# Altium schematic sheet alimentation.SchDoc
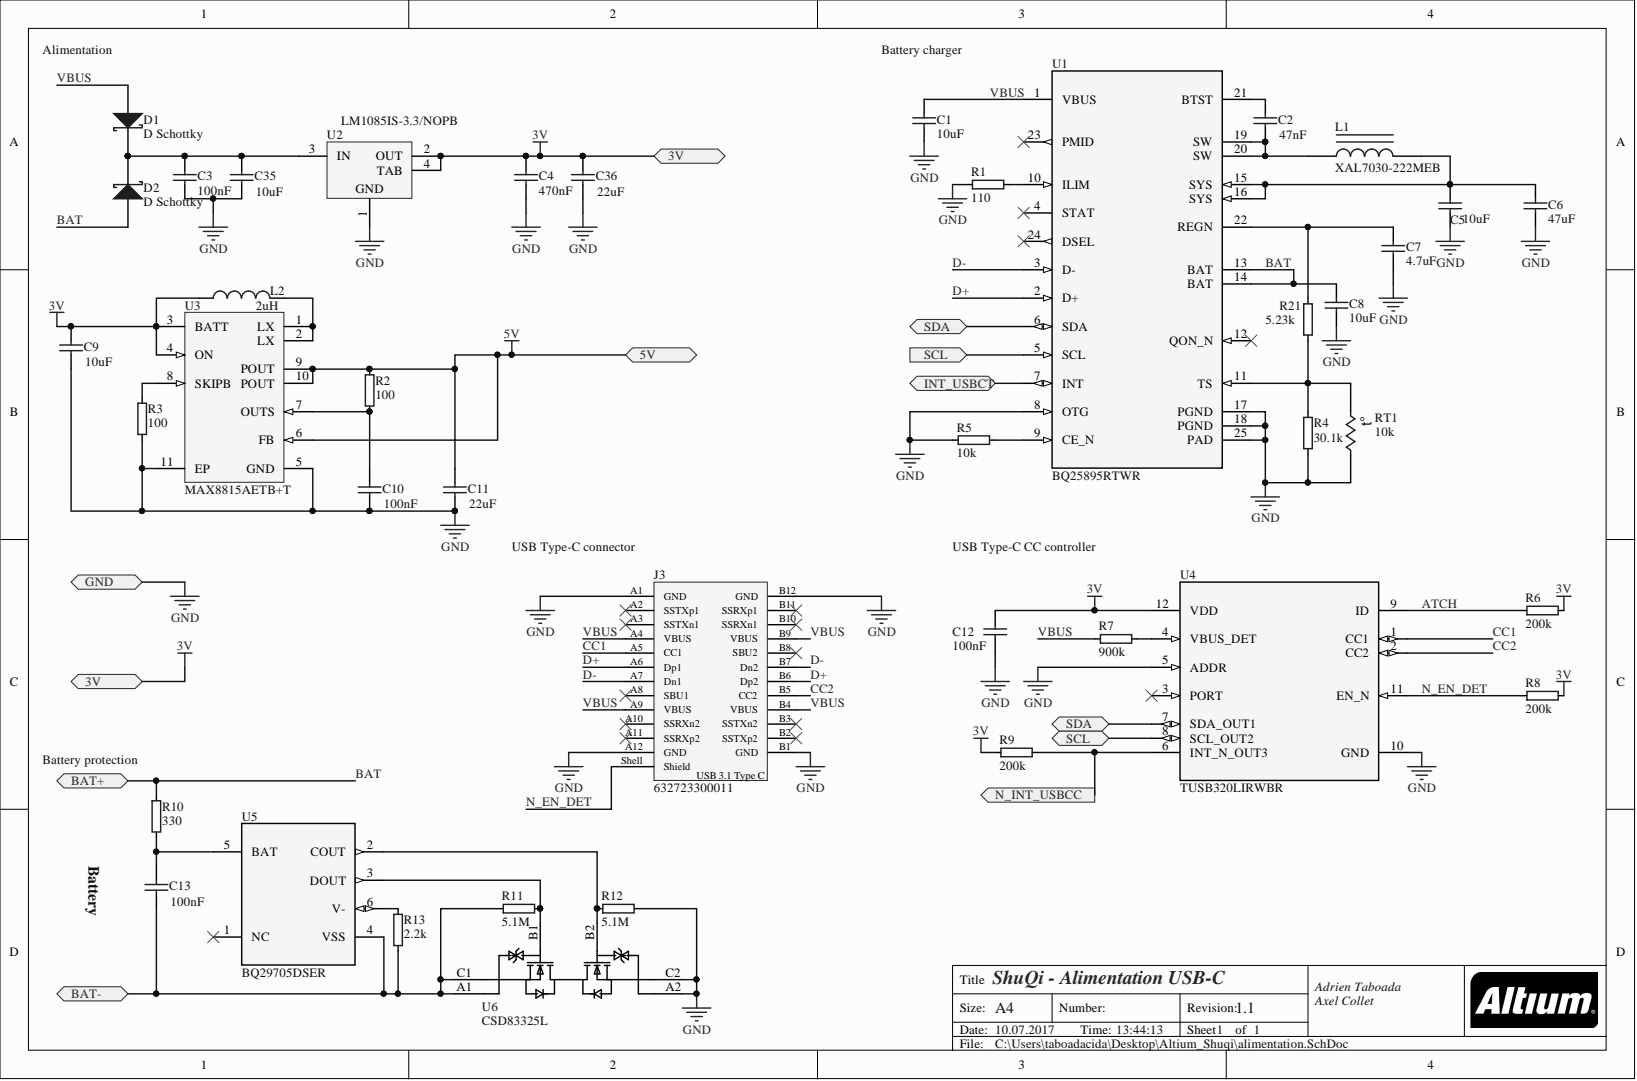
[diagram p0: alimentation.SchDoc]

## Parts
C1  10uF  [1608[0603]]
C2  47nF  [1608[0603]]
C3  100nF  [1608[0603]]
C4  470nF  [1608[0603]]
C5  10uF  [1608[0603]]
C6  47uF  [1608[0603]]
C7  4.7uF  [1608[0603]]
C8  10uF  [1608[0603]]
C9  10uF  [1608[0603]]
C10  100nF  [1608[0603]]
C11  22uF  [1608[0603]]
C12  100nF  [1608[0603]]
C13  100nF  [1608[0603]]
C35  10uF  [1608[0603]]
C36  22uF  [1608[0603]]
D1  D Schottky  [SMB]
D2  D Schottky  [SMB]
J3  632723300011  Interface Type: Type C  Sub-Family: USB 3.1  [632723300011]
L1  XAL7030-222MEB  [DFN472P750X310-2N]
L2  2uH  [TYS80_Inductance]
R1  110  [RESC1005X04L]
R2  100  [RESC1005X04L]
R3  100  [RESC1005X04L]
R4  30.1k  [RESC1005X04L]
R5  10k  [RESC1005X04L]
R6  200k  [RESC1005X04L]
R7  900k  [RESC1005X04L]
R8  200k  [RESC1005X04L]
R9  200k  [RESC1005X04L]
R10  330  [RESC1005X04L]
R11  5.1M  [RESC1005X04L]
R12  5.1M  [RESC1005X04L]
R13  2.2k  [RESC1005X04L]
R21  5.23k  [RESC1005X04L]
RT1  10k  [6-0805_N]
U1  BQ25895RTWR  [RTW0024H]
U2  LM1085IS-3.3/NOPB  [KTT0003B_M]
U3  MAX8815AETB+T  [T1033+2_M]
U4  TUSB320LIRWBR  [RWB0012A]
U5  BQ29705DSER  [DSE0006A]
U6  CSD83325L  [YJE0006A]

## Ports
3V, 5V, BAT+, BAT-, GND, INT_USBCT, N_INT_USBCC, SCL, SDA

## Nets
3V: C4.2 C9.2 C12.2 C36.2 L2.1 R6.1 R8.1 R9.2 U2.2(OUT) U2.4(TAB) U3.3(BATT) U3.4(ON) U4.12(VDD) ; port 3V
5V: C11.2 R2.2 U3.6(FB) U3.9(POUT) U3.10(POUT) ; port 5V
ATCH: R6.2 U4.9(ID)
BAT: C8.1 D2.1 R10.2 U1.13(BAT) U1.14(BAT)
CC1: J3.A5(CC1) U4.1(CC1)
CC2: J3.B5(CC2) U4.2(CC2)
D+: J3.A6(Dp1) J3.B6(Dp2) U1.2(D+)
D-: J3.A7(Dn1) J3.B7(Dn2) U1.3(D-)
GND: C1.2 C3.1 C4.1 C5.2 C6.2 C7.2 C8.2 C9.1 C10.1 C11.1 C12.1 C35.1 C36.1 J3.A1(GND) J3.A12(GND) J3.B1(GND) J3.B12(GND) R1.2 R3.1 R4.1 R5.2 R12.1 RT1.2 U1.8(OTG) U1.17(PGND) U1.18(PGND) U1.25(PAD) U2.1 U3.5(GND) U3.11(EP) U4.5(ADDR) U4.10(GND) U6.A2 U6.C2 ; port GND
INT_USBCT: U1.7(INT) ; port INT_USBCT
N_EN_DET: J3.Shell(Shield) R8.2 U4.11(EN_N)
N_INT_USBCC: R9.1 U4.6(INT_N_OUT3) ; port N_INT_USBCC
SCL: U1.5(SCL) U4.8(SCL_OUT2) ; port SCL
SDA: U1.6(SDA) U4.7(SDA_OUT1) ; port SDA
VBUS: C1.1 D1.1 J3.A4(VBUS) J3.A9(VBUS) J3.B4(VBUS) J3.B9(VBUS) R7.2 U1.1(VBUS)
NetC2_1: C2.1 U1.21(BTST)
NetC2_2: C2.2 L1.1 U1.19(SW) U1.20(SW)
NetC3_2: C3.2 C35.2 D1.2 D2.2 U2.3(IN)
NetC5_1: C5.1 C6.1 L1.2 U1.15(SYS) U1.16(SYS)
NetC7_1: C7.1 R21.2 U1.22(REGN)
NetC10_2: C10.2 R2.1 U3.7(OUTS)
NetC13_1: C13.1 R11.2 R13.1 U5.4(VSS) U6.A1 U6.C1
NetC13_2: C13.2 R10.1 U5.5(BAT)
NetL2_2: L2.2 U3.1(LX) U3.2(LX)
NetR1_1: R1.1 U1.10(ILIM)
NetR3_2: R3.2 U3.8(SKIPB)
NetR4_2: R4.2 R21.1 RT1.1 U1.11(TS)
NetR5_1: R5.1 U1.9(CE_N)
NetR7_1: R7.1 U4.4(VBUS_DET)
NetR11_1: R11.1 U5.3(DOUT) U6.B1
NetR12_2: R12.2 U5.2(COUT) U6.B2
NetR13_2: R13.2 U5.6(V-)

Not connected: J3 A2(SSTXp1) A3(SSTXn1) A8(SBU1) A10(SSRXn2) A11(SSRXp2) B2(SSTXp2) B3(SSTXn2) B8(SBU2) B10(SSRXn1) B11(SSRXp1); U1 4(STAT) 12(QON_N) 23(PMID) 24(DSEL); U4 3(PORT); U5 1(NC)

## Library data of the parts
C1 C5 C8 C9 C35 C36 = Cap Semi: Capacitor (Semiconductor SIM Model); Manufacturer Part Number: GRM188R61C106MAALD; Component Kind: Standard; LatestRevisionDate: 29-May-2009; LatestRevisionNote: IPC-7351 Footprint Added.; Library Name: Miscellaneous Devices.IntLib; PackageDescription: Chip Capacitor; Published: 8-Jun-2000; Signal Integrity: Cap Semi; Simulation: CAP; Publisher: Altium Limited
  C1: PackageReference: C1206; Value: 10uF
  C5 C8 C9 C35: PackageReference: C0603; Value: 10uF
  C36: PackageReference: C0603; Value: 22uF
C2 = Cap Semi: Capacitor (Semiconductor SIM Model); Manufacturer Part Number: GCM188R71H473KA55J; Component Kind: Standard; LatestRevisionDate: 29-May-2009; LatestRevisionNote: IPC-7351 Footprint Added.; Library Name: Miscellaneous Devices.IntLib; PackageDescription: Chip Capacitor; PackageReference: C0603; Published: 8-Jun-2000; Signal Integrity: Cap Semi; Simulation: CAP; Value: 47nF; Publisher: Altium Limited
C3 C10 C12 C13 = Cap Semi: Capacitor (Semiconductor SIM Model); Manufacturer Part Number: GRM188R71H104KA93D; Component Kind: Standard; LatestRevisionDate: 29-May-2009; LatestRevisionNote: IPC-7351 Footprint Added.; Library Name: Miscellaneous Devices.IntLib; PackageDescription: Chip Capacitor; Published: 8-Jun-2000; Signal Integrity: Cap Semi; Simulation: CAP; Value: 100nF; Publisher: Altium Limited
  C3 C12: PackageReference: C1206
  C10 C13: PackageReference: C0603
C4 = Cap Semi: Capacitor (Semiconductor SIM Model); Manufacturer Part Number: GRM188R71C474KA88D; Component Kind: Standard; LatestRevisionDate: 29-May-2009; LatestRevisionNote: IPC-7351 Footprint Added.; Library Name: Miscellaneous Devices.IntLib; PackageDescription: Chip Capacitor; PackageReference: C1206; Published: 8-Jun-2000; Signal Integrity: Cap Semi; Simulation: CAP; Value: 470nF; Publisher: Altium Limited
C6 = Cap Semi: Capacitor (Semiconductor SIM Model); Manufacturer Part Number: GRM188R60J476ME15D; Component Kind: Standard; LatestRevisionDate: 29-May-2009; LatestRevisionNote: IPC-7351 Footprint Added.; Library Name: Miscellaneous Devices.IntLib; PackageDescription: Chip Capacitor; PackageReference: C0603; Published: 8-Jun-2000; Signal Integrity: Cap Semi; Simulation: CAP; Value: 47uF; Publisher: Altium Limited
C7 = Cap Semi: Capacitor (Semiconductor SIM Model); Manufacturer Part Number: GRM188R61C475KAAJD; Component Kind: Standard; LatestRevisionDate: 29-May-2009; LatestRevisionNote: IPC-7351 Footprint Added.; Library Name: Miscellaneous Devices.IntLib; PackageDescription: Chip Capacitor; PackageReference: C0603; Published: 8-Jun-2000; Signal Integrity: Cap Semi; Simulation: CAP; Value: 4.7uF; Publisher: Altium Limited
C11 = Cap Semi: Capacitor (Semiconductor SIM Model); Manufacturer Part Number: GRM188R60J226MEA0D; Component Kind: Standard; LatestRevisionDate: 29-May-2009; LatestRevisionNote: IPC-7351 Footprint Added.; Library Name: Miscellaneous Devices.IntLib; PackageDescription: Chip Capacitor; PackageReference: C0603; Published: 8-Jun-2000; Signal Integrity: Cap Semi; Simulation: CAP; Value: 22uF; Publisher: Altium Limited
D1 D2 = D Schottky: Schottky Diode; Manufacturer Part Number: CDBB360-HF; Code_JEDEC: DO-214-AA; Component Kind: Standard; LatestRevisionDate: 17-Jul-2002; LatestRevisionNote: Re-released for DXP Platform.; Library Name: Miscellaneous Devices.IntLib; PackageDescription: DO-214-AA/SMB; 2 C-Bend Leads; Body 5.3 x 3.6 mm, inc. leads (LxW); PackageReference: SMB; Published: 8-Jun-2000; Signal Integrity: D Schottky; Simulation: SKYDIODE; Publisher: Altium Limited
J3 = 632723300011: USB 3.1 Type C Receptacle Horizontal THR WR-COM; Component Kind: Standard; ComponentLink1Description: Manufacturer; ComponentLink1URL: http://www.we-online.de; ComponentLink2Description: Datasheet; ComponentLink2URL: http://katalog.we-online.de/em/datasheet/632723x00011.pdf; Construction: THR; Gender: Receptacle; Library Name: ALTIUM-WR-COM-rev17a.IntLib; Manufacturer Part Number: 632723300011; Part Number: 632723300011; Published Date: April 2017; Type: Horizontal; Publisher: Wurth Elektronik
L1 = Inductor Iron: Magnetic-Core Inductor; Component Kind: Standard; LatestRevisionDate: 17-Jul-2002; LatestRevisionNote: Re-released for DXP Platform.; Library Name: Miscellaneous Devices.IntLib; Manufacturer Part Number: XAL7030-222MEB; PackageDescription: Axial Device, Thru-Hole; 2 Leads; 0.9 in Pin Spacing; PackageReference: AXIAL-0.9; Published: 24-Mar-1999; Signal Integrity: Inductor Iron; Simulation: INDUCTOR; Value: XAL7030-222MEB; Publisher: Altium Limited
L2 = Inductor: Manufacturer Part Number: TYS80402R0N-10; Code_IEC: 1005; Code_JEITA: 0402; Component Kind: Standard; Description: Inductor; LatestRevisionDate: 29-May-2009; LatestRevisionNote: IPC-7351 Footprint Added.; Library Name: Miscellaneous Devices.IntLib; PackageDescription: Chip Inductor; PackageReference: 0402-A; Published: 8-Jun-2000; Signal Integrity: Inductor; Simulation: INDUCTOR; Value: 2uH; Publisher: Altium Limited
R1 = R0402-220: Resistor, ±1%, 0.063W; Manufacturer Part Number: RC0402FR-07110RL; Artikkelnr Noca: 27049057; Artikkelnr Simpro: N.A.; Database Table Name: Resistors$; Note: Chip Res 1%; Component Kind: Standard; Footprint Doc: 0402; LatestRevisionDate: 2009-11-23; Library Name: Nordic Passive DB.DbLib; Part Number: R0402-220; Pin Count: 2; Published: 2009-05-08; Supplier: Farnell; Supplier part number: 173-8841; Value: 110; Publisher: Nordic Semiconductor
R2 R3 = R0402-220: Resistor, ±1%, 0.063W; Manufacturer Part Number: RC0402FR-07100RL; Artikkelnr Noca: 27049057; Artikkelnr Simpro: N.A.; Database Table Name: Resistors$; Note: Chip Res 1%; Component Kind: Standard; Footprint Doc: 0402; LatestRevisionDate: 2009-11-23; Library Name: Nordic Passive DB.DbLib; Part Number: R0402-220; Pin Count: 2; Published: 2009-05-08; Supplier: Farnell; Supplier part number: 173-8841; Value: 100; Publisher: Nordic Semiconductor
R4 R21 = R0402-220: Resistor, ±1%, 0.063W; Manufacturer Part Number: RC0402FR-0730K1L; Artikkelnr Noca: 27049057; Artikkelnr Simpro: N.A.; Database Table Name: Resistors$; Note: Chip Res 1%; Component Kind: Standard; Footprint Doc: 0402; LatestRevisionDate: 2009-11-23; Library Name: Nordic Passive DB.DbLib; Part Number: R0402-220; Pin Count: 2; Published: 2009-05-08; Supplier: Farnell; Supplier part number: 173-8841; Publisher: Nordic Semiconductor
  R4: Value: 30.1k
  R21: Value: 5.23k
R5 = R0402-220: Resistor, ±1%, 0.063W; Manufacturer Part Number: RC0402FR-0710KL; Artikkelnr Noca: 27049057; Artikkelnr Simpro: N.A.; Database Table Name: Resistors$; Note: Chip Res 1%; Component Kind: Standard; Footprint Doc: 0402; LatestRevisionDate: 2009-11-23; Library Name: Nordic Passive DB.DbLib; Part Number: R0402-220; Pin Count: 2; Published: 2009-05-08; Supplier: Farnell; Supplier part number: 173-8841; Value: 10k; Publisher: Nordic Semiconductor
R6 R8 R9 = R0402-220: Resistor, ±1%, 0.063W; Manufacturer Part Number: RC0402FR-07200KL; Artikkelnr Noca: 27049057; Artikkelnr Simpro: N.A.; Database Table Name: Resistors$; Note: Chip Res 1%; Component Kind: Standard; Footprint Doc: 0402; LatestRevisionDate: 2009-11-23; Library Name: Nordic Passive DB.DbLib; Part Number: R0402-220; Pin Count: 2; Published: 2009-05-08; Supplier: Farnell; Supplier part number: 173-8841; Value: 200k; Publisher: Nordic Semiconductor
R7 = R0402-220: Resistor, ±1%, 0.063W; Manufacturer Part Number: RC0402FR-07887KL; Artikkelnr Noca: 27049057; Artikkelnr Simpro: N.A.; Database Table Name: Resistors$; Note: Chip Res 1%; Component Kind: Standard; Footprint Doc: 0402; LatestRevisionDate: 2009-11-23; Library Name: Nordic Passive DB.DbLib; Part Number: R0402-220; Pin Count: 2; Published: 2009-05-08; Supplier: Farnell; Supplier part number: 173-8841; Value: 900k; Publisher: Nordic Semiconductor
R10 = R0402-220: Resistor, ±1%, 0.063W; Manufacturer Part Number: RC0402FR-07330RL; Artikkelnr Noca: 27049057; Artikkelnr Simpro: N.A.; Database Table Name: Resistors$; Note: Chip Res 1%; Component Kind: Standard; Footprint Doc: 0402; LatestRevisionDate: 2009-11-23; Library Name: Nordic Passive DB.DbLib; Part Number: R0402-220; Pin Count: 2; Published: 2009-05-08; Supplier: Farnell; Supplier part number: 173-8841; Value: 330; Publisher: Nordic Semiconductor
R11 R12 = R0402-220: Resistor, ±1%, 0.063W; Manufacturer Part Number: RC0402FR-075M1L; Artikkelnr Noca: 27049057; Artikkelnr Simpro: N.A.; Database Table Name: Resistors$; Note: Chip Res 1%; Component Kind: Standard; Footprint Doc: 0402; LatestRevisionDate: 2009-11-23; Library Name: Nordic Passive DB.DbLib; Part Number: R0402-220; Pin Count: 2; Published: 2009-05-08; Supplier: Farnell; Supplier part number: 173-8841; Value: 5.1M; Publisher: Nordic Semiconductor
R13 = R0402-220: Resistor, ±1%, 0.063W; Manufacturer Part Number: AC0402FR-072K2L; Artikkelnr Noca: 27049057; Artikkelnr Simpro: N.A.; Database Table Name: Resistors$; Note: Chip Res 1%; Component Kind: Standard; Footprint Doc: 0402; LatestRevisionDate: 2009-11-23; Library Name: Nordic Passive DB.DbLib; Part Number: R0402-220; Pin Count: 2; Published: 2009-05-08; Supplier: Farnell; Supplier part number: 173-8841; Value: 2.2k; Publisher: Nordic Semiconductor
RT1 = Res Thermal: Thermistor; Manufacturer Part Number: NTCS0805E3103FHT; Code_IPC: RESC2012; Component Kind: Standard; LatestRevisionDate: 29-May-2009; LatestRevisionNote: IPC-7351 Footprint Added.; Library Name: Miscellaneous Devices.IntLib; PackageDescription: Chip Resistor 0805 Case Size; PackageReference: 6-0805; PackageVersion: Mar-2003; Published: 24-Mar-1999; Value: 10k; Publisher: Altium Limited
U1 = BQ25895RTWR: Battery charger; Alternate_PartNumber: BQ25895RTWT; Manufacturer: Texas Instruments; CompType: IC; PIN_COUNT: 25; Component Kind: Standard; Library Name: BQ25895RTWR.SchLib; Manufacturer Part Number: BQ25895RTWR; MountType: SMT; PackageReference: RTW0024H; PartNumber: BQ25895RTWR; RefDes: RefDes; TYPE: TYPE; Value: Value
U2 = CMP-0062-00578-4: 3A Low Dropout Positive Regulators, 3-pin TO-263, Pb-Free; Manufacturer: Texas Instruments; CompType: LDO IC; High-Reliability: Y; PackageTopMarking: NSUZXYTTE#*LM1085*IS-3.3; PowerWise: N; Code_JEDEC: MO-169-AA; Component Kind: Standard; ComponentLink1Description: Datasheet URL; ComponentLink1URL: http://www.ti.com/general/docs/lit/getliterature.tsp?genericPartNumber=LM1085&fileType=pdf; Library Name: Altium Content Vault; PackageDescription: TO-263, Molded, .400 x .390 x .180in, 3 Lead, .1in Pitch, Surface Mount; PackageReference: KTT0003B; PartNumber: LM1085IS-3.3/NOPB; PinCount: 3; RoHS: Y
U3 = CMP-0246-00645-1: 1A, 97% Efficiency, 30uA Quiescent Current, Step-Up Converter with True Shutdown(TM), 10-Pin TDFN-EP, -40°C to +85°C, Pb-Free, Tape and Reel; User Parameter 1: Manufacturer Part Number; Code_JEDEC: MO-229-WEED-3; Component Kind: Standard; ComponentLink1Description: Manufacturer URL; ComponentLink1URL: http://www.maxim-ic.com; ComponentLink2Description: Datasheet; ComponentLink2URL: http://datasheets.maxim-ic.com/en/ds/MAX8815A.pdf; ComponentLink3Description: Package Specification; ComponentLink3URL: http://pdfserv.maxim-ic.com/package_dwgs/21-0137.PDF; DatasheetVersion: Rev 1, Aug-2008; Library Name: Altium Content Vault; Manufacturer Part Number: MAX8815AETB+T; PackageDescription: 10-Pin Plastic Very Very Thin Dual Flatpack No Leads, Exposed Pad, 3 x 3 x 0.8 mm Body, TDFN-EP, Pb-Free; PackageReference: T1033+2; PackageVersion: revJ, 2009
U4 = TUSB320LIRWBR: USB Type-C Configuration Channel Logic and Port Control; Manufacturer: Texas Instruments; CompType: IC; PIN_COUNT: 12; Component Kind: Standard; Library Name: TUSB320LIRWBR.SchLib; Manufacturer Part Number: TUSB320LIRWBR; MountType: SMT; PackageReference: RWB0012A; PartNumber: TUSB320LIRWBR; RefDes: RefDes; RoHS: Y; TYPE: TYPE; Value: Value
U5 = BQ29705DSER: Battery protection; Manufacturer: Texas Instruments; Component Kind: Standard; Library Name: BQ29705DSER.SchLib; Manufacturer Part Number: BQ29705DSER; PartNumber: BQ29705DSER; RefDes: RefDes; TYPE: TYPE; Value: Value
U6 = CSD83325L: MOSFET; Manufacturer: Texas Instruments; ChType: N; PIN_COUNT: 6; QgTyp_nC: 8.4; Value: V; Value1: ? ohm; Value2: 8.4 nC; VALUE_2: Value; Vgs_V: 10; Component Kind: Standard; CompType: MOSFET; LatestRevisionNote: Added parts.; Library Name: CSD83325L.SchLib; Manufacturer Part Number: CSD83325L; MountType: SMT; PackageReference: LGA, 6-Leads, Body 2.16x1.11mm, Pitch 0.65mm; PartNumber: CSD83325L; Published: 2008-06-19; RefDes: RefDes; RoHS: Y; Supplier_1: Digi-Key; Supplier_2: Mouser; Supplier_Part_Number_1: CSD83325L-ND; Supplier_Part_Number_2: 595-CSD83325L; TYPE: TYPE; Value: Value
